# Altium schematic sheet Capacitors.SchDoc(Capacitors)
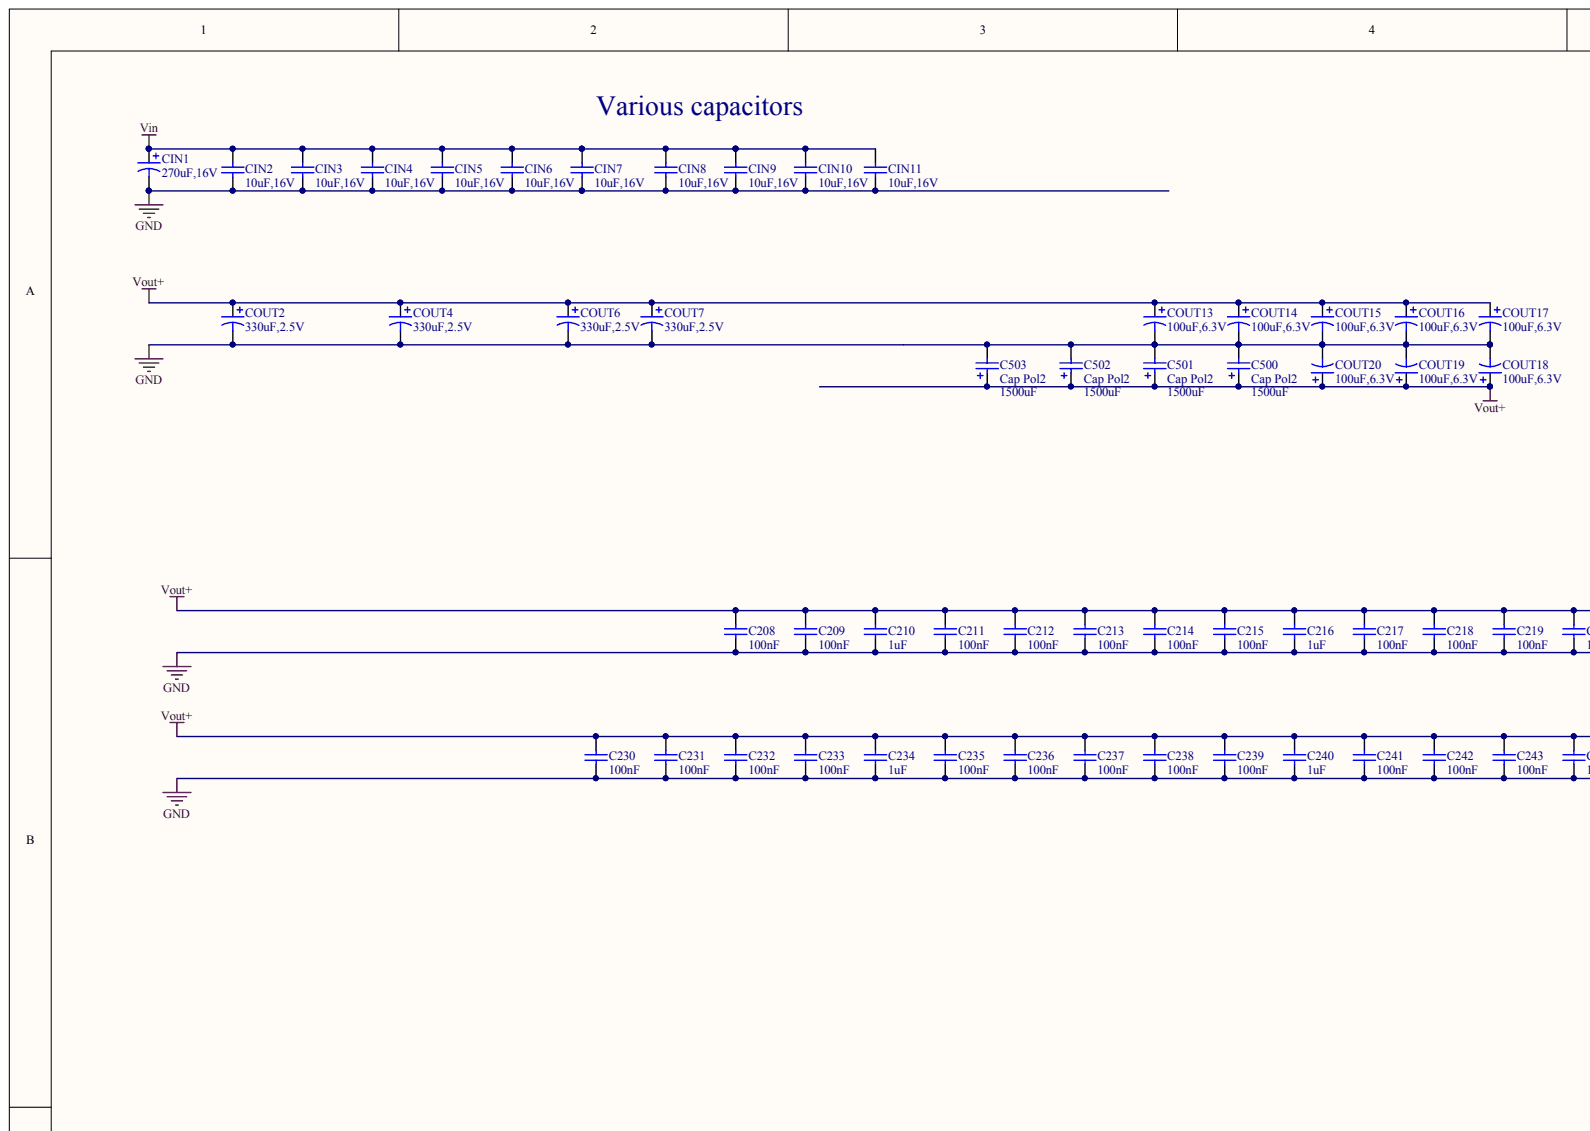
[diagram p0: Capacitors.SchDoc, top left]
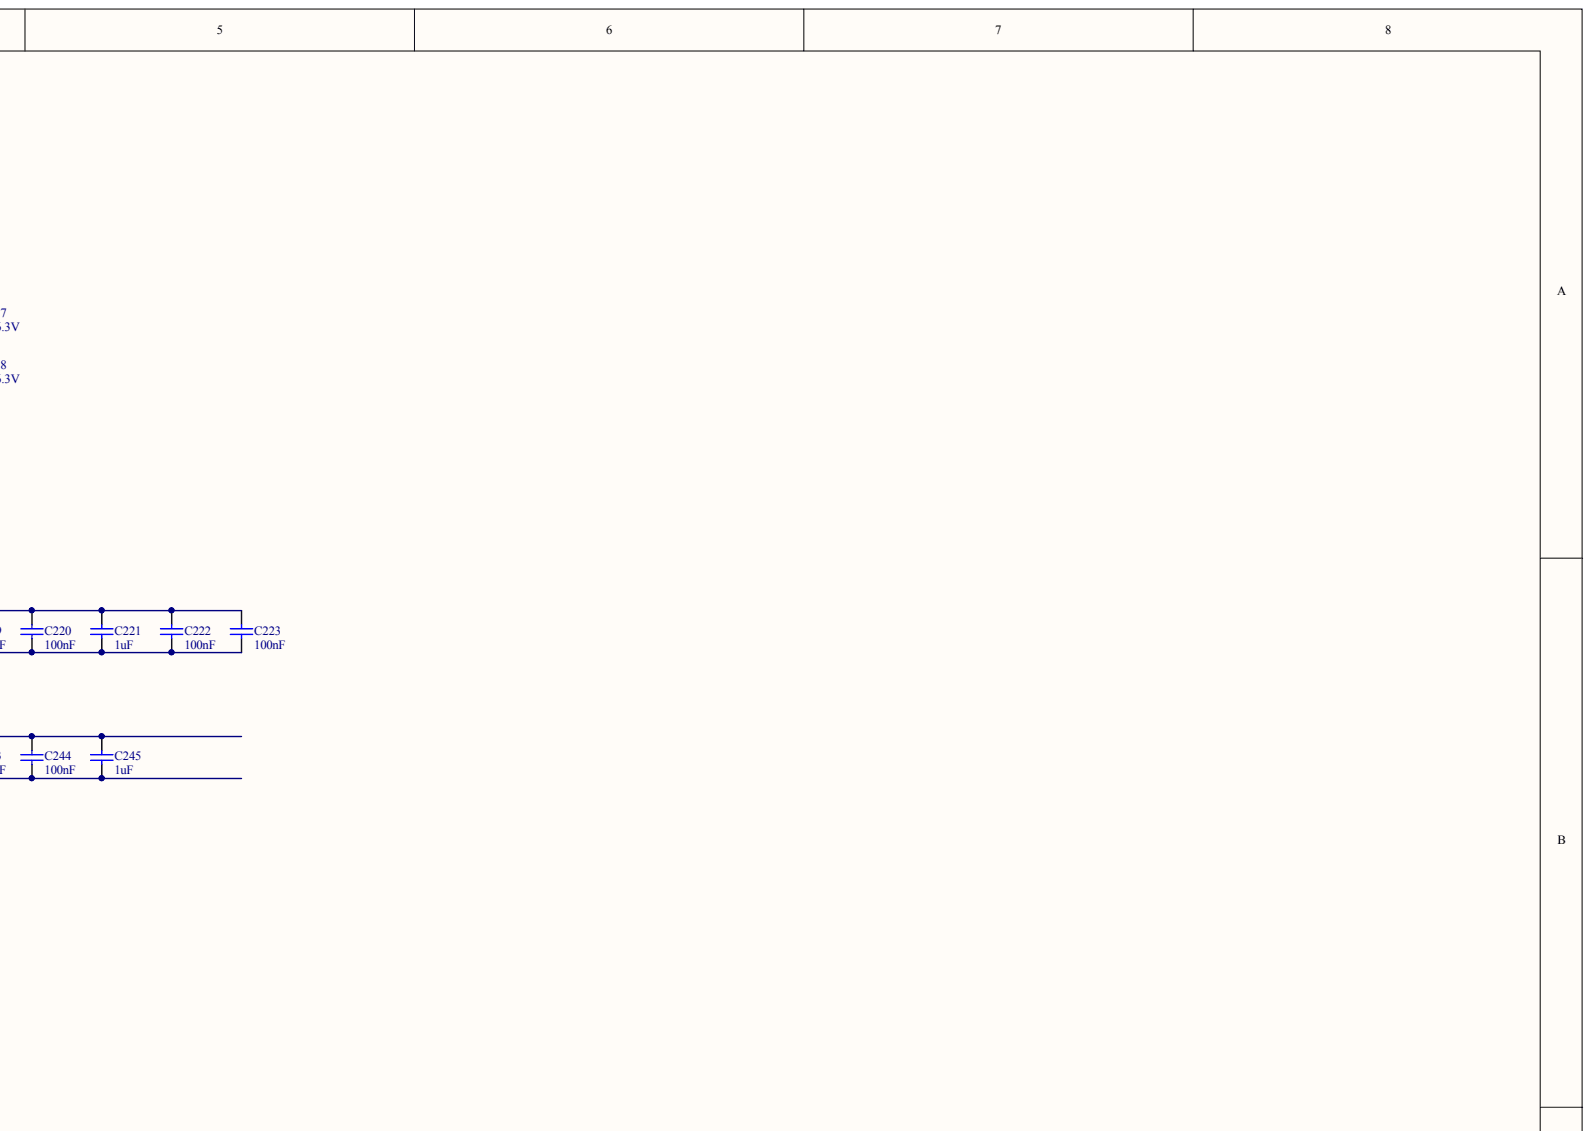
[diagram p1: Capacitors.SchDoc, top right]
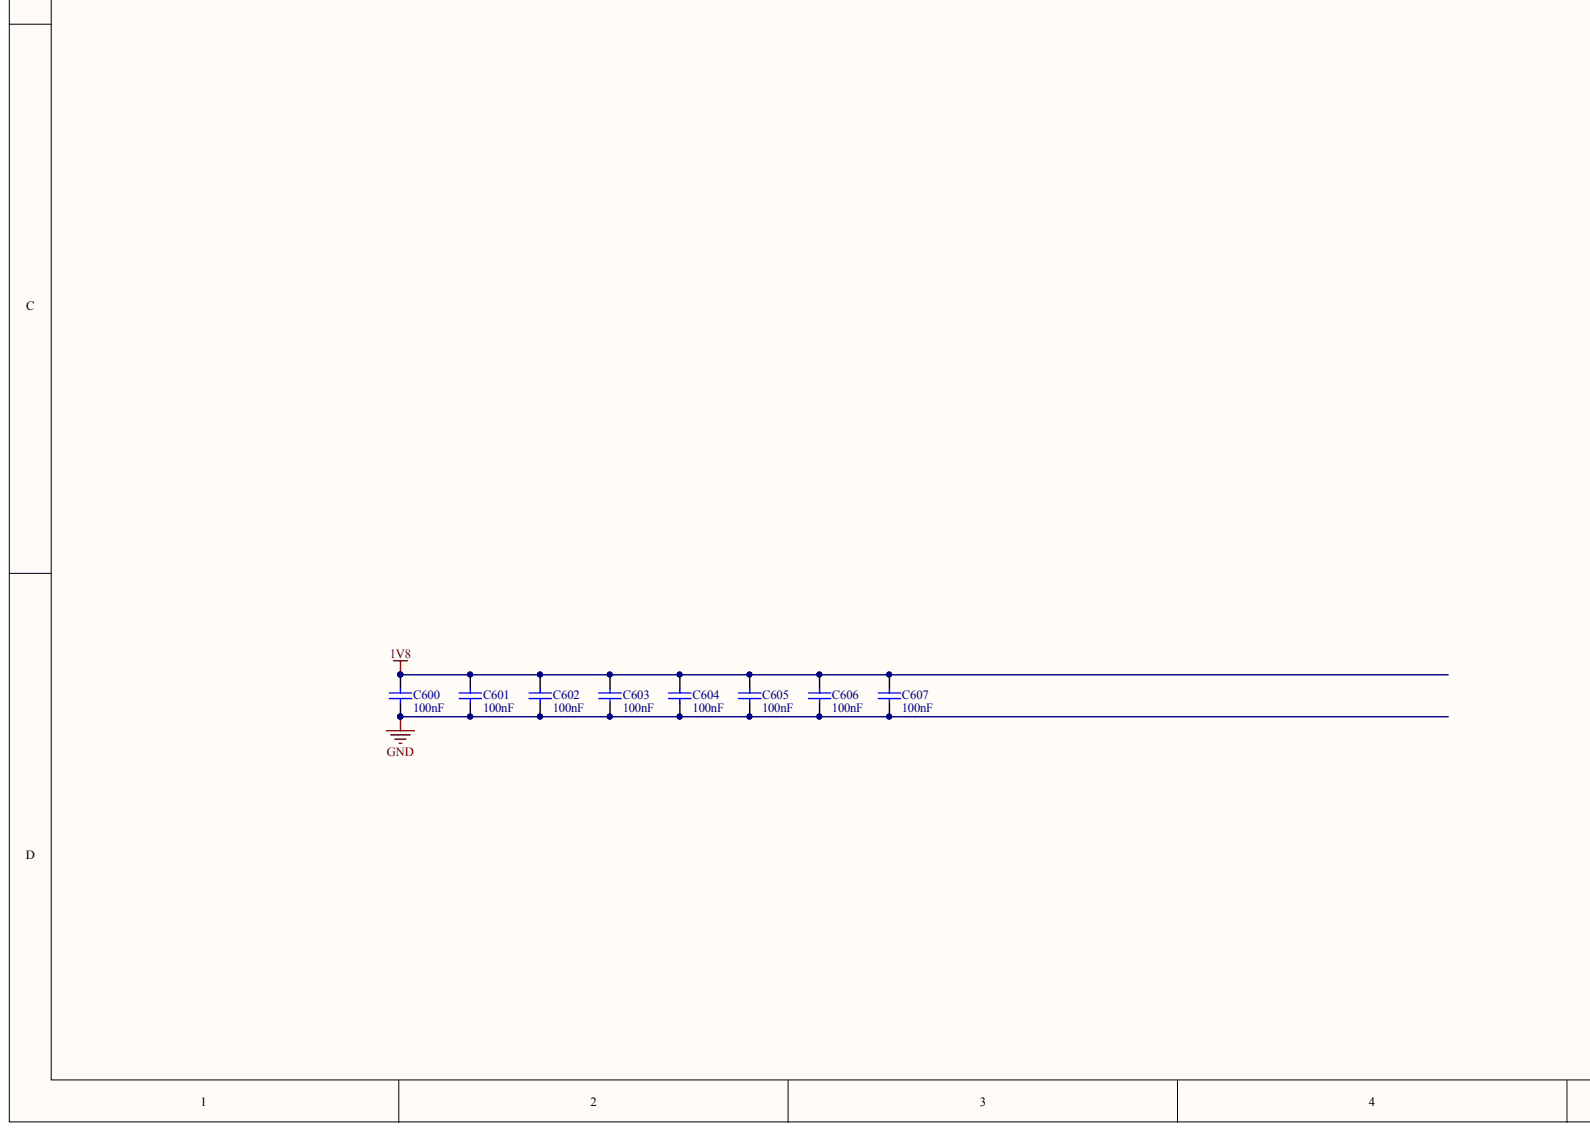
[diagram p2: Capacitors.SchDoc, bottom left]
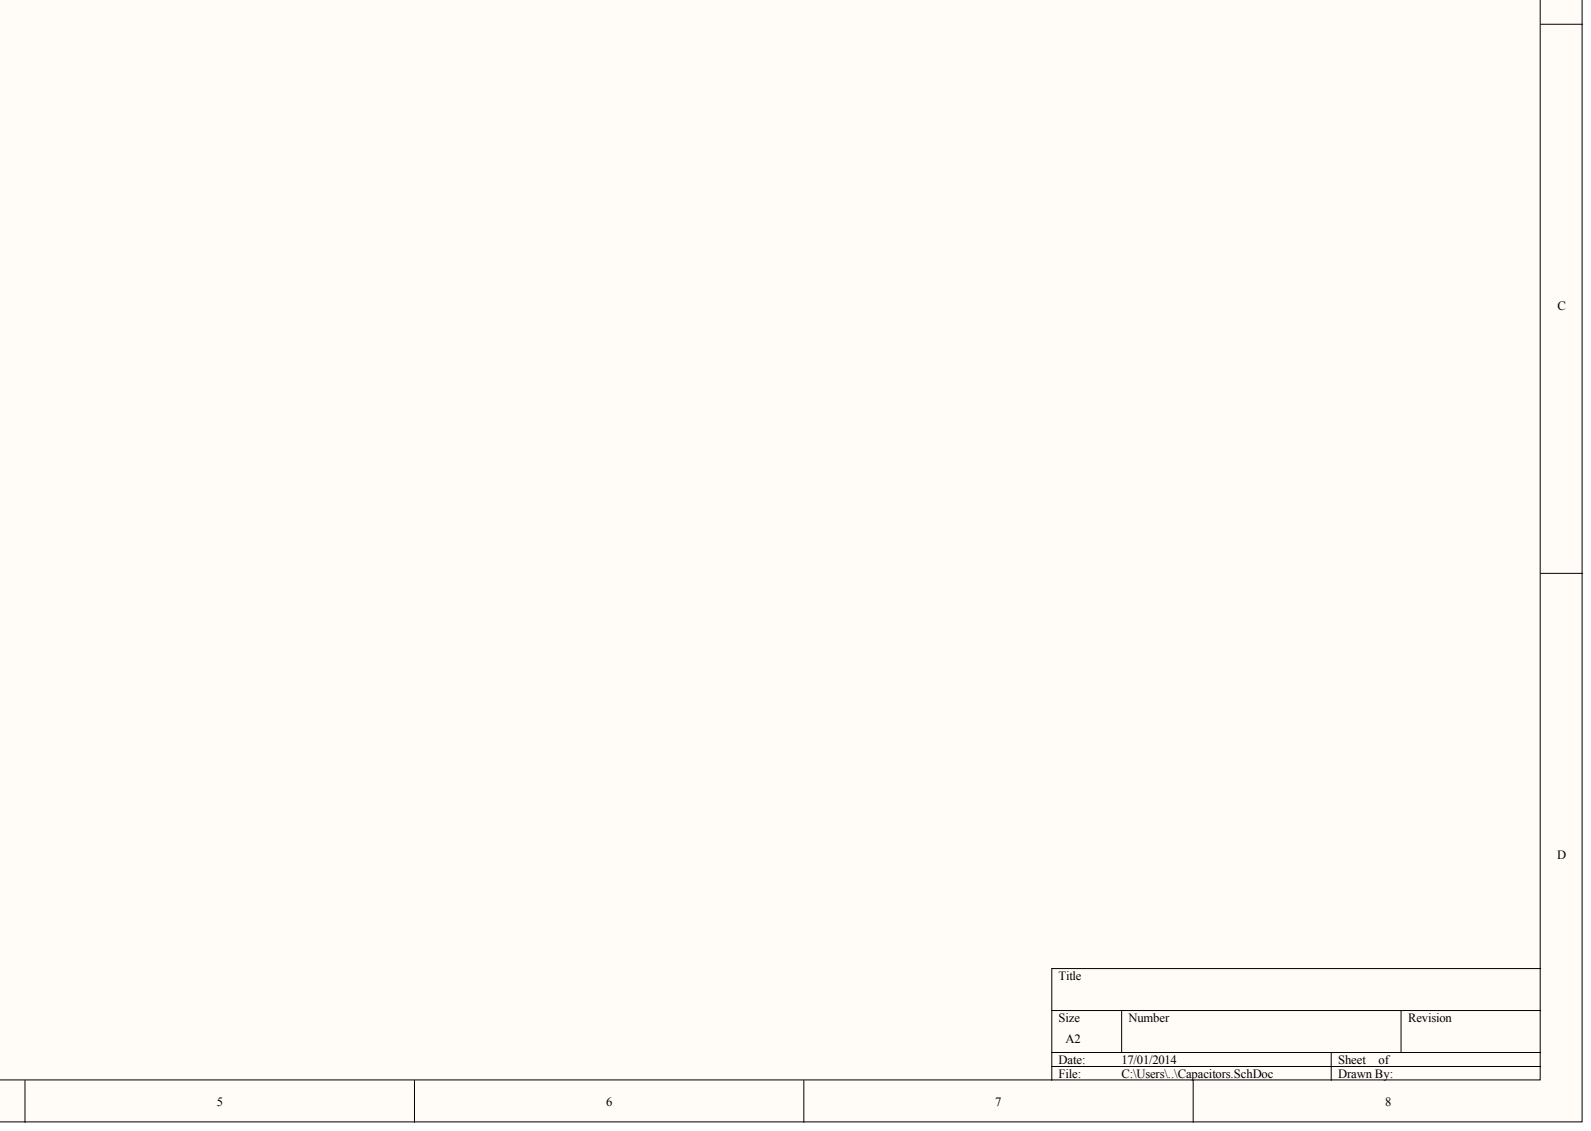
[diagram p3: Capacitors.SchDoc, bottom right]

## Parts
C208  100nF
C209  100nF
C210  1uF
C211  100nF
C212  100nF
C213  100nF
C214  100nF
C215  100nF
C216  1uF
C217  100nF
C218  100nF
C219  100nF
C220  100nF
C221  1uF
C222  100nF
C223  100nF
C230  100nF
C231  100nF
C232  100nF
C233  100nF
C234  1uF
C235  100nF
C236  100nF
C237  100nF
C238  100nF
C239  100nF
C240  1uF
C241  100nF
C242  100nF
C243  100nF
C244  100nF
C245  1uF
C500  Cap Pol2  value 1500uF
C501  Cap Pol2  value 1500uF
C502  Cap Pol2  value 1500uF
C503  Cap Pol2  value 1500uF
C600  100nF
C601  100nF
C602  100nF
C603  100nF
C604  100nF
C605  100nF
C606  100nF
C607  100nF
CIN1  270uF,16V
CIN2  10uF,16V
CIN3  10uF,16V
CIN4  10uF,16V
CIN5  10uF,16V
CIN6  10uF,16V
CIN7  10uF,16V
CIN8  10uF,16V
CIN9  10uF,16V
CIN10  10uF,16V
CIN11  10uF,16V
COUT2  330uF,2.5V
COUT4  330uF,2.5V
COUT6  330uF,2.5V
COUT7  330uF,2.5V
COUT13  100uF,6.3V
COUT14  100uF,6.3V
COUT15  100uF,6.3V
COUT16  100uF,6.3V
COUT17  100uF,6.3V
COUT18  100uF,6.3V
COUT19  100uF,6.3V
COUT20  100uF,6.3V

## Nets
1V8: C600.2 C601.2 C602.2 C603.2 C604.2 C605.2 C606.2 C607.2
GND: C208.1 C209.1 C210.1 C211.1 C212.1 C213.1 C214.1 C215.1 C216.1 C217.1 C218.1 C219.1 C220.1 C221.1 C222.1 C223.1 C230.1 C231.1 C232.1 C233.1 C234.1 C235.1 C236.1 C237.1 C238.1 C239.1 C240.1 C241.1 C242.1 C243.1 C244.1 C245.1 C500.2 C501.2 C502.2 C503.2 C600.1 C601.1 C602.1 C603.1 C604.1 C605.1 C606.1 C607.1 CIN1.2 CIN2.1 CIN3.1 CIN4.1 CIN5.1 CIN6.1 CIN7.1 CIN8.1 CIN9.1 CIN10.1 CIN11.1 COUT2.2 COUT4.2 COUT6.2 COUT7.2 COUT13.2 COUT14.2 COUT15.2 COUT16.2 COUT17.2 COUT18.2 COUT19.2 COUT20.2
VIN: CIN1.1 CIN2.2 CIN3.2 CIN4.2 CIN5.2 CIN6.2 CIN7.2 CIN8.2 CIN9.2 CIN10.2 CIN11.2
VOUT+: C208.2 C209.2 C210.2 C211.2 C212.2 C213.2 C214.2 C215.2 C216.2 C217.2 C218.2 C219.2 C220.2 C221.2 C222.2 C223.2 C230.2 C231.2 C232.2 C233.2 C234.2 C235.2 C236.2 C237.2 C238.2 C239.2 C240.2 C241.2 C242.2 C243.2 C244.2 C245.2 C500.1 C501.1 C502.1 C503.1 COUT2.1 COUT4.1 COUT6.1 COUT7.1 COUT13.1 COUT14.1 COUT15.1 COUT16.1 COUT17.1 COUT18.1 COUT19.1 COUT20.1

## Library data of the parts
C208-C223 C230-C245 C500-C503 C600-C607 CIN1-CIN11 COUT2 COUT4 COUT6 COUT7 COUT13-COUT20 = (no symbol name): Published: 8-Jun-2000; LatestRevisionDate: 17-Jul-2002; LatestRevisionNote: Re-released for DXP Platform.; Publisher: Altium Limited
  C208 C209 C211-C215 C217-C220 C222 C223 C230-C233 C235-C239 C241-C244 C600-C607: PackageReference: RAD-0.3; PackageDescription: Radial Cap, Thru-Hole; 2 Leads; 0.3 in Pin Spacing; Value: 100nF
  C210 C216 C221 C234 C240 C245: PackageReference: RAD-0.3; PackageDescription: Radial Cap, Thru-Hole; 2 Leads; 0.3 in Pin Spacing; Value: 1uF
  C500-C503: PackageReference: POLAR0.8; PackageDescription: Polarized Capacitor; 2 Leads
  CIN1: PackageReference: RB7.6-15; PackageDescription: Polarized Capacitor (Radial); 2 Leads; Value: 270uF,16V
  CIN2-CIN11: PackageReference: RAD-0.3; PackageDescription: Radial Cap, Thru-Hole; 2 Leads; 0.3 in Pin Spacing; Value: 10uF,16V
  COUT2 COUT4 COUT6 COUT7: PackageReference: RB7.6-15; PackageDescription: Polarized Capacitor (Radial); 2 Leads; Value: 330uF,2.5V
  COUT13-COUT20: PackageReference: RB7.6-15; PackageDescription: Polarized Capacitor (Radial); 2 Leads; Value: 100uF,6.3V
